# Altium schematic sheet Raijin_Peripherals.SchDoc
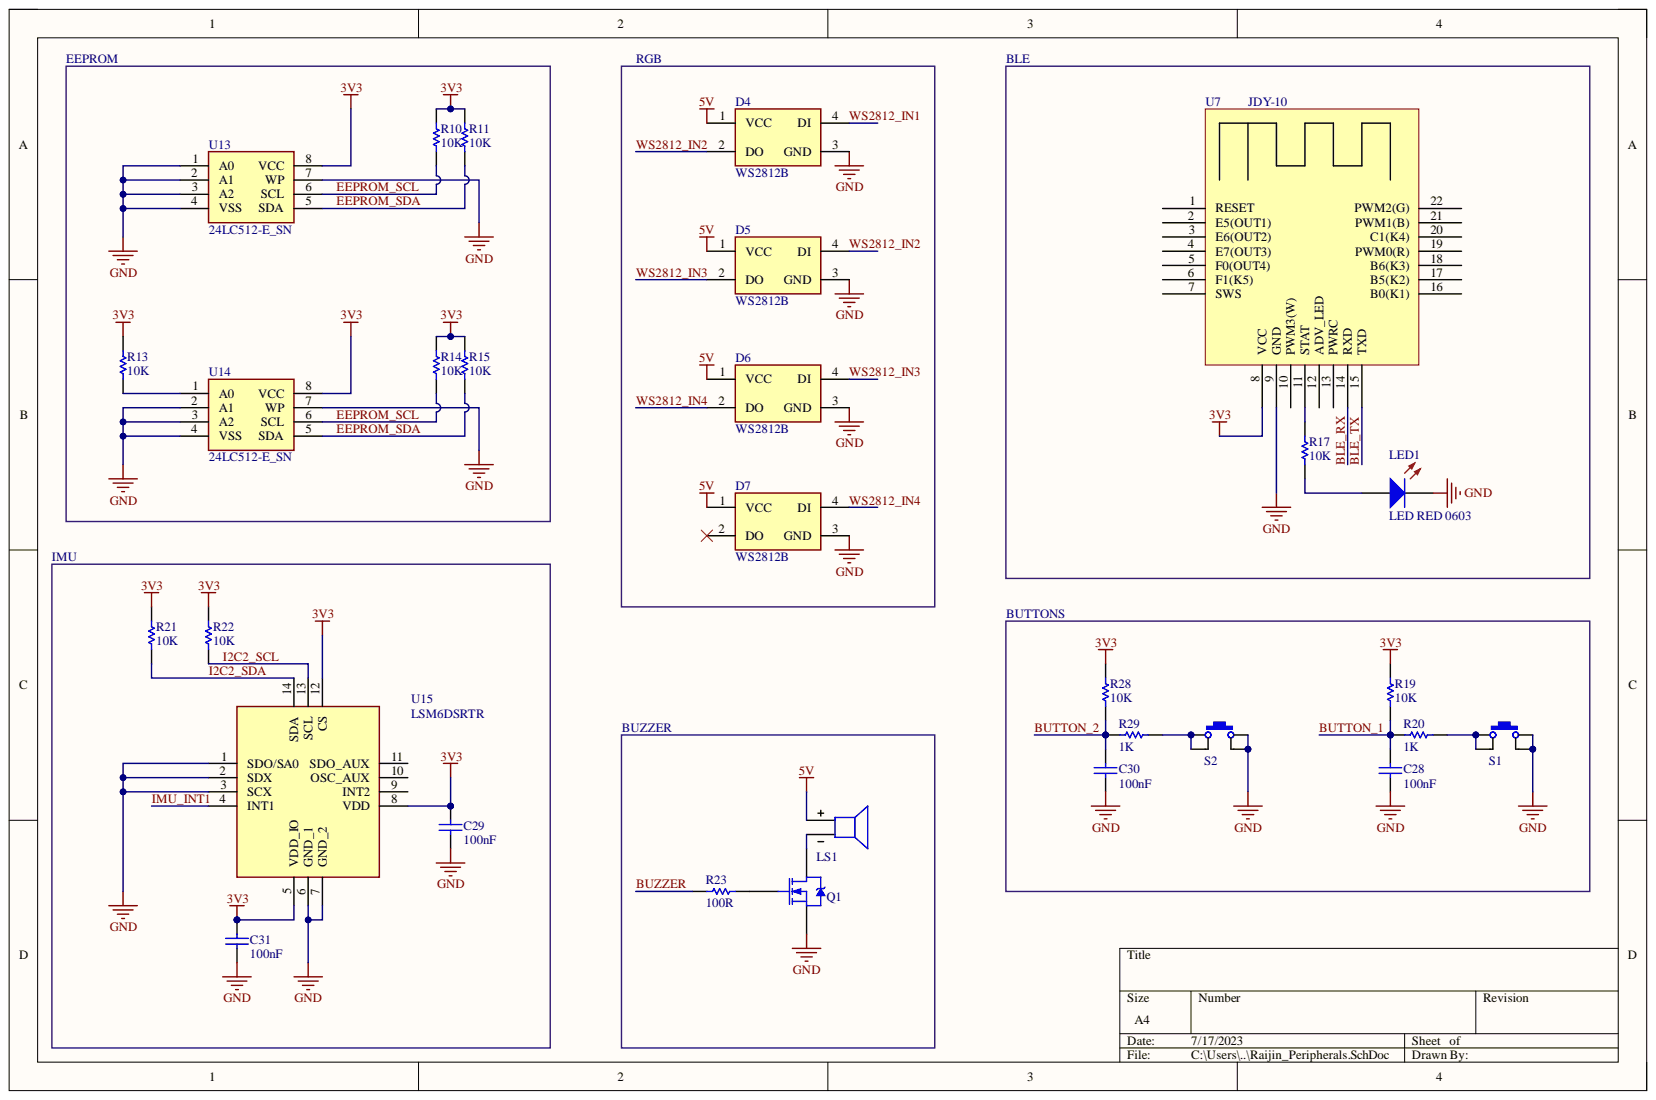
[diagram p0: Raijin_Peripherals.SchDoc]

## Parts
C28  100nF  [Capacitor-0603]
C29  100nF  [Capacitor-0603]
C30  100nF  [Capacitor-0603]
C31  100nF  [Capacitor-0603]
D4  WS2812B  [WS2812B]
D5  WS2812B  [WS2812B]
D6  WS2812B  [WS2812B]
D7  WS2812B  [WS2812B]
LED1  LED RED 0603  [LED 0603]
LS1  Buzzer  [BUZZER 12V]  (value not drawn)
Q1  BSS138  [BSS138-SOT223]  (value not drawn)
R10  10K  [RESISTOR 0603]
R11  10K  [RESISTOR 0603]
R13  10K  [RESISTOR 0603]
R14  10K  [RESISTOR 0603]
R15  10K  [RESISTOR 0603]
R17  10K  [RESISTOR 0603]
R19  10K  [RESISTOR 0603]
R20  1K  [RESISTOR 0603]
R21  10K  [RESISTOR 0603]
R22  10K  [RESISTOR 0603]
R23  100R  [RESISTOR 0603]
R28  10K  [RESISTOR 0603]
R29  1K  [RESISTOR 0603]
S1  SPST-NO  [TACT SWITCH 6X6X7MM]  (value not drawn)
S2  SPST-NO  [TACT SWITCH 6X6X7MM]  (value not drawn)
U7  JDY-10  [JDY-10]
U13  24LC512-E_SN  [SOIC127P600X175-8N]
U14  24LC512-E_SN  [SOIC127P600X175-8N]
U15  LSM6DSRTR  [QFN50P300X250X86-14N]

## Nets
3V3: C29.2 C31.1 R10.2 R11.2 R13.2 R14.2 R15.2 R19.2 R21.1 R22.1 R28.2 U7.8(VCC) U13.8(VCC) U14.8(VCC) U15.5(VDD_IO) U15.8(VDD) U15.12(CS)
5V: D4.1(VCC) D5.1(VCC) D6.1(VCC) D7.1(VCC) LS1.1
GND: C28.1 C29.1 C30.1 C31.2 D4.3(GND) D5.3(GND) D6.3(GND) D7.3(GND) LED1.2 Q1.2 S1.3 S1.4 S2.3 S2.4 U7.9(GND) U13.1(A0) U13.2(A1) U13.3(A2) U13.4(VSS) U13.7(WP) U14.2(A1) U14.3(A2) U14.4(VSS) U14.7(WP) U15.1(SDO/SA0) U15.2(SDX) U15.3(SCX) U15.6(GND_1) U15.7(GND_2)
BLE_RX: U7.14(RXD)
BLE_TX: U7.15(TXD)
BUTTON_1: C28.2 R19.1 R20.1
BUTTON_2: C30.2 R28.1 R29.1
BUZZER: R23.1
EEPROM_SCL: R10.1 R14.1 U13.6(SCL) U14.6(SCL)
EEPROM_SDA: R11.1 R15.1 U13.5(SDA) U14.5(SDA)
I2C2_SCL: R22.2 U15.13(SCL)
I2C2_SDA: R21.2 U15.14(SDA)
IMU_INT1: U15.4(INT1)
WS2812_IN1: D4.4(DI)
WS2812_IN2: D4.2(DO) D5.4(DI)
WS2812_IN3: D5.2(DO) D6.4(DI)
WS2812_IN4: D6.2(DO) D7.4(DI)
NetLED1_1: LED1.1 R17.1
NetLS1_2: LS1.2 Q1.3
NetQ1_1: Q1.1 R23.2
NetR13_1: R13.1 U14.1(A0)
NetR17_2: R17.2 U7.11(STAT)
NetR20_2: R20.2 S1.1 S1.2
NetR29_2: R29.2 S2.1 S2.2

Not connected: D7 2(DO); U7 1(RESET) 2(E5(OUT1)) 3(E6(OUT2)) 4(E7(OUT3)) 5(F0(OUT4)) 6(F1(K5)) 7(SWS) 10(PWM3(W)) 12(ADV_LED) 13(PWRC) 16(B0(K1)) 17(B5(K2)) 18(B6(K3)) 19(PWM0(R)) 20(C1(K4)) 21(PWM1(B)) 22(PWM2(G)); U15 9(INT2) 10(OSC_AUX) 11(SDO_AUX)

## Library data of the parts
C28 C30 = CAP 100NF 50V: Capacitor Cer 100nF 50V; Manufacturer Part Number: IMP14925; Capacitance: 0.1uF; Capacitance Tolerance: +80%, -20%; Capacitor Terminals: Radial Leaded; Dielectric Characteristic: Y5; Product Range: MCFY Series; SVHC: No SVHC (15-Jun-2015); Voltage Rating: 50; Capacitance Tolerance ±: +80%, -20%; Component Kind: Standard; ComponentLink1Description: http://loja.multcomercial.com.br/capacitor-ceramico-disco-100kpf-50v-104-100k-100nf-0-1uf-cod-1351.html; ComponentLink1URL: http://loja.multcomercial.com.br/capacitor-ceramico-disco-100kpf-50v-104-100k-100nf-0-1uf-cod-1351.html; Lead Spacing: 5; Manufacturer: -; Operating Temperature Max: 85; Operating Temperature Min: -25; Operating Temperature Range: -25°C to +85°C<!--FANDB--><div class="features-and-benefits-container"><div class="features-and-benefits-description"><span class="features-benefits-value">The MCFY series from Multicomp are radial leaded ceramic disc capacitors. The capacitance values ranges from 6800pF to 0.22?F.</span></div><div class="features-and-benefits-list"><ul class="features-and-benefits-list-list"><li class="features-and-benefits-list-item">Large capacitance in small size</li><li class="features-and-benefits-list-item">Rated voltage of 50V</li><li class="features-and-benefits-list-item">80%/-20% tolerance</li><li class="features-and-benefits-list-item">Operating temperature range -25°C to +85; Packaging: Each; Pricing 1: 1=0.10 (BRL); RoHS: YES; Stock 1: 115809; Supplier 1: Mult Comercial; Supplier Part Number 1: Capacitor Cerâmico Disco 100Kpf/50V (104/100K/100nF/0.1uF) cód. 1351; Library Name: RaijinLib.SchLib
C29 C31 = CAP 100NF 16V: Capacitor Cer 100nF 16VX7R; Manufacturer Part Number: IMP14925; Capacitance: 0.1uF; Capacitance Tolerance: +80%, -20%; Capacitor Terminals: Radial Leaded; Dielectric Characteristic: Y5; Product Range: MCFY Series; SVHC: No SVHC (15-Jun-2015); Voltage Rating: 50; Capacitance Tolerance ±: +80%, -20%; Component Kind: Standard; ComponentLink1Description: http://loja.multcomercial.com.br/capacitor-ceramico-disco-100kpf-50v-104-100k-100nf-0-1uf-cod-1351.html; ComponentLink1URL: http://loja.multcomercial.com.br/capacitor-ceramico-disco-100kpf-50v-104-100k-100nf-0-1uf-cod-1351.html; Lead Spacing: 5; Manufacturer: -; Operating Temperature Max: 85; Operating Temperature Min: -25; Operating Temperature Range: -25°C to +85°C<!--FANDB--><div class="features-and-benefits-container"><div class="features-and-benefits-description"><span class="features-benefits-value">The MCFY series from Multicomp are radial leaded ceramic disc capacitors. The capacitance values ranges from 6800pF to 0.22?F.</span></div><div class="features-and-benefits-list"><ul class="features-and-benefits-list-list"><li class="features-and-benefits-list-item">Large capacitance in small size</li><li class="features-and-benefits-list-item">Rated voltage of 50V</li><li class="features-and-benefits-list-item">80%/-20% tolerance</li><li class="features-and-benefits-list-item">Operating temperature range -25°C to +85; Packaging: Each; Pricing 1: 1=0.10 (BRL); RoHS: YES; Stock 1: 115809; Supplier 1: Mult Comercial; Supplier Part Number 1: Capacitor Cerâmico Disco 100Kpf/50V (104/100K/100nF/0.1uF) cód. 1351; Library Name: RaijinLib.SchLib
D4-D7 = WS2812B: Diode; Manufacturer_Name: Worldsemi; Manufacturer_Part_Number: WS2812; Component Kind: Standard; Datasheet Link: https://www.adafruit.com/datasheets/WS2812.pdf; Library Name: RaijinLib.SchLib
LED1 = LED RED 0603: LED; Manufacturer_Name: ROHM Semiconductor; Manufacturer_Part_Number: SML-D12U1WT86; Component Kind: Standard; Datasheet Link: https://componentsearchengine.com/Datasheets/1/SML-D12U1WT86.pdf; Height: 0.65mm; Mouser Part Number: 755-SML-D12U1WT86; Mouser Price/Stock: https://www.mouser.co.uk/ProductDetail/ROHM-Semiconductor/SML-D12U1WT86?qs=M3jcYzEJUdEySuGkbjHEtQ%3D%3D; Library Name: RaijinLib.SchLib
LS1 = Buzzer Piezo 5V: Driver Circuitry: Transducer, Externally Driven; Frequency: 4kHz; Input Type: Zero-Peak Signal; Operating Mode: Single Tone; Port Location: Top; Sound Pressure Level: 60dB @ 3V, 10cm; Voltage - Rated: 3V; Voltage Range: 30V (Max); Approvals: -; Category: Audio Products; Component Kind: Standard; ComponentLink1Description: https://product.tdk.com/info/en/catalog/datasheets/ef532_ps.pdf; ComponentLink1URL: https://product.tdk.com/info/en/catalog/datasheets/ef532_ps.pdf; Current - Supply: -; Duration: -; Height - Seated (Max): 0.307" (7.80mm); Mounting Type: Through Hole; Operating Temperature: -10°C ~ 70°C; Packaging: Cut Tape (CT); Part Status: Active; Pricing 1: 1=0.72, 10=0.622, 100=0.3889, 250=0.37776 (USD); Ratings: -; RoHS: RoHS Compliant; Size / Dimension: 0.480" Dia (12.20mm); Stock 1: 23730; Supplier 1: Digi-Key; Supplier Part Number 1: 445-2525-1-ND; Technology: Piezo; Termination: PC Pins; Library Name: RaijinLib.SchLib
Q1 = BSS138: N-Channel Logic Level  Enhancement Mode Field  Effect Transisto; Manufacturer: Nexperia; Manufacturer Part Number: PSMN1R0-30YLDX; Component Kind: Standard; Pricing 1: 10=1.17, 25=1.07, 100=0.824, 250=0.746, 500=0.668, 1000=0.513, 5000=0.503 (GBP); Stock 1: 0; Supplier 1: Farnell; Library Name: RaijinLib.SchLib
R10 R11 R13-R15 R17 R19 R21 R22 R28 = RES 10K 5%: Manufacturer: PANASONIC ELECTRONIC COMPONENTS; Manufacturer Part Number: ERJ-3GEYJ103V; Power (Watts): 0.1W, 1/10W; Temperature Coefficient: ±200ppm/°C; Tolerance: ±5%; Category: Resistors; Component Kind: Standard; ComponentLink1Description: http://www.farnell.com/datasheets/1678796.pdf; ComponentLink1URL: http://www.farnell.com/datasheets/1678796.pdf; ComponentLink2Description: http://industrial.panasonic.com/www-cgi/jvcr13pz.cgi?E+PZ+3+AOA0001+ERJ3GEYJ103V+7+WW; ComponentLink2URL: http://industrial.panasonic.com/www-cgi/jvcr13pz.cgi?E+PZ+3+AOA0001+ERJ3GEYJ103V+7+WW; Composition: Thick Film; Features: Automotive AEC-Q200; Height: 0.022" (0.55mm); Number of Terminations: 2; Operating Temperature: -55°C ~ 155°C; Package / Case: 0603 (1608 Metric); Packaging: Tape & Reel (TR); Resistance (Ohms): 10k; RoHS: YES; Size / Dimension: 0.063" L x 0.031" W (1.60mm x 0.80mm); Supplier Device Package: 0603; Library Name: RaijinLib.SchLib
R20 R29 = RES 1K 5%: Manufacturer: Ty-Ohm; Manufacturer Part Number: CR25; Power (Watts): 0.25W, 1/4W; Temperature Coefficient: 0/ -500ppm/°C; Tolerance: ±5%; Category: Resistors; Component Kind: Standard; ComponentLink1Description: http://loja.multcomercial.com.br/resistor-de-1-4-de-watt-cr25-5-de-tolerancia.html; ComponentLink1URL: http://loja.multcomercial.com.br/resistor-de-1-4-de-watt-cr25-5-de-tolerancia.html; Composition: Carbon Film; Features: Flame Retardant Coating, Safety; Height: -; Number of Terminations: 2; Operating Temperature: -55°C ~ 155°C; Package / Case: Axial; Packaging: Cut Tape (CT); Pricing 1: 1=0.20 (BRL); Resistance (Ohms): ?; RoHS: RoHS Compliant; Size / Dimension: 0.091" Dia x 0.256" L (2.30mm x 6.50mm); Stock 1: 617543; Supplier 1: Mult Comercial; Supplier Device Package: Axial; Supplier Part Number 1: Resistor de Filme de Carbono 1/4 de Watt CR25 5% de tolerância - 0.22R Ω à 10M Ω; Library Name: RaijinLib.SchLib
R23 = RES 100R 5%: Manufacturer: Ty-Ohm; Manufacturer Part Number: CR25; Power (Watts): 0.25W, 1/4W; Temperature Coefficient: 0/ -500ppm/°C; Tolerance: ±5%; Category: Resistors; Component Kind: Standard; ComponentLink1Description: http://loja.multcomercial.com.br/resistor-de-1-4-de-watt-cr25-5-de-tolerancia.html; ComponentLink1URL: http://loja.multcomercial.com.br/resistor-de-1-4-de-watt-cr25-5-de-tolerancia.html; Composition: Carbon Film; Features: Flame Retardant Coating, Safety; Height: -; Number of Terminations: 2; Operating Temperature: -55°C ~ 155°C; Package / Case: Axial; Packaging: Cut Tape (CT); Pricing 1: 1=0.20 (BRL); Resistance (Ohms): ?; RoHS: RoHS Compliant; Size / Dimension: 0.091" Dia x 0.256" L (2.30mm x 6.50mm); Stock 1: 617543; Supplier 1: Mult Comercial; Supplier Device Package: Axial; Supplier Part Number 1: Resistor de Filme de Carbono 1/4 de Watt CR25 5% de tolerância - 0.22R Ω à 10M Ω; Library Name: RaijinLib.SchLib
S1 S2 = Switch Tactile: Switch Tactile SPST-NO 0.02A 15V; Manufacturer: Panasonic Electronic Components; Manufacturer Part Number: EVQ-PAC07K; Actuator Height off PCB, from Pins: 7.00mm; Actuator Orientation: Top Actuated; Actuator Type: Standard; Contact Rating @ Voltage: 0.02A @ 15VDC; Illumination: Non-Illuminated; Mechanical Life: 100,000 Cycles; Operating Force: 100gf; Outline: 6.00mm x 6.00mm; Switch Function: Off-Mom; Switch Travel: 0.25mm; Actuator Material: -; Category: Switches; Circuit: SPST-NO; Component Kind: Standard; ComponentLink1Description: http://industrial.panasonic.com/cdbs/www-data/pdf/ATK0000/ATK0000CE10.pdf; ComponentLink1URL: http://industrial.panasonic.com/cdbs/www-data/pdf/ATK0000/ATK0000CE10.pdf; Features: -; Illumination Type, Color: -; Illumination Voltage (Nominal): -; Ingress Protection: -; Mounting Type: Through Hole; Operating Temperature: -20°C ~ 70°C; Packaging: Bulk; Part Status: Active; Pricing 1: 1=0.27, 10=0.254, 25=0.2168, 50=0.1896, 100=0.176, 250=0.15572, 500=0.14894, 1000=0.12186, 5000=0.10832 (USD); RoHS: RoHS Compliant; Stock 1: 19805; Supplier 1: Digi-Key; Supplier Part Number 1: P8011S-ND; Termination Style: PC Pin; Library Name: RaijinLib.SchLib
U7 = JDY-10-Bluetooth: Component Kind: Standard; ComponentLink1Description: Datasheet; ComponentLink1URL: http://myosuploads3.banggood.com/products/20190515/20190515052519JDY-10M.pdf; Library Name: RaijinLib.SchLib
U13 U14 = 24LC512-E_SN: Integrated Circuit; Manufacturer_Name: Microchip; Manufacturer_Part_Number: 24LC512-E/SN; Arrow Part Number: 24LC512-E/SN; Arrow Price/Stock: https://www.arrow.com/en/products/24lc512-esn/microchip-technology?region=nac; Component Kind: Standard; Datasheet Link: http://www.microchip.com/mymicrochip/filehandler.aspx?ddocname=en546203; Height: 1.75mm; Mouser Part Number: 579-24LC512-E/SN; Mouser Price/Stock: https://www.mouser.co.uk/ProductDetail/Microchip-Technology/24LC512-E-SN?qs=CtbZ3JXzXSq04R8z3pTI3A%3D%3D; Library Name: RaijinLib.SchLib
U15 = LSM6DSRTR: IMUs - Inertial Measurement Units; Manufacturer_Name: STMicroelectronics; Geometry.Height: 0.86mm; Component Kind: Standard; Datasheet Link: https://componentsearchengine.com/Datasheets/2/LSM6DSRTR.pdf; Manufacturer_Part_Number: LSM6DSRTR; Library Name: RaijinLib.SchLib
